# Altium schematic sheet ADXL335_Breakout.SchDoc
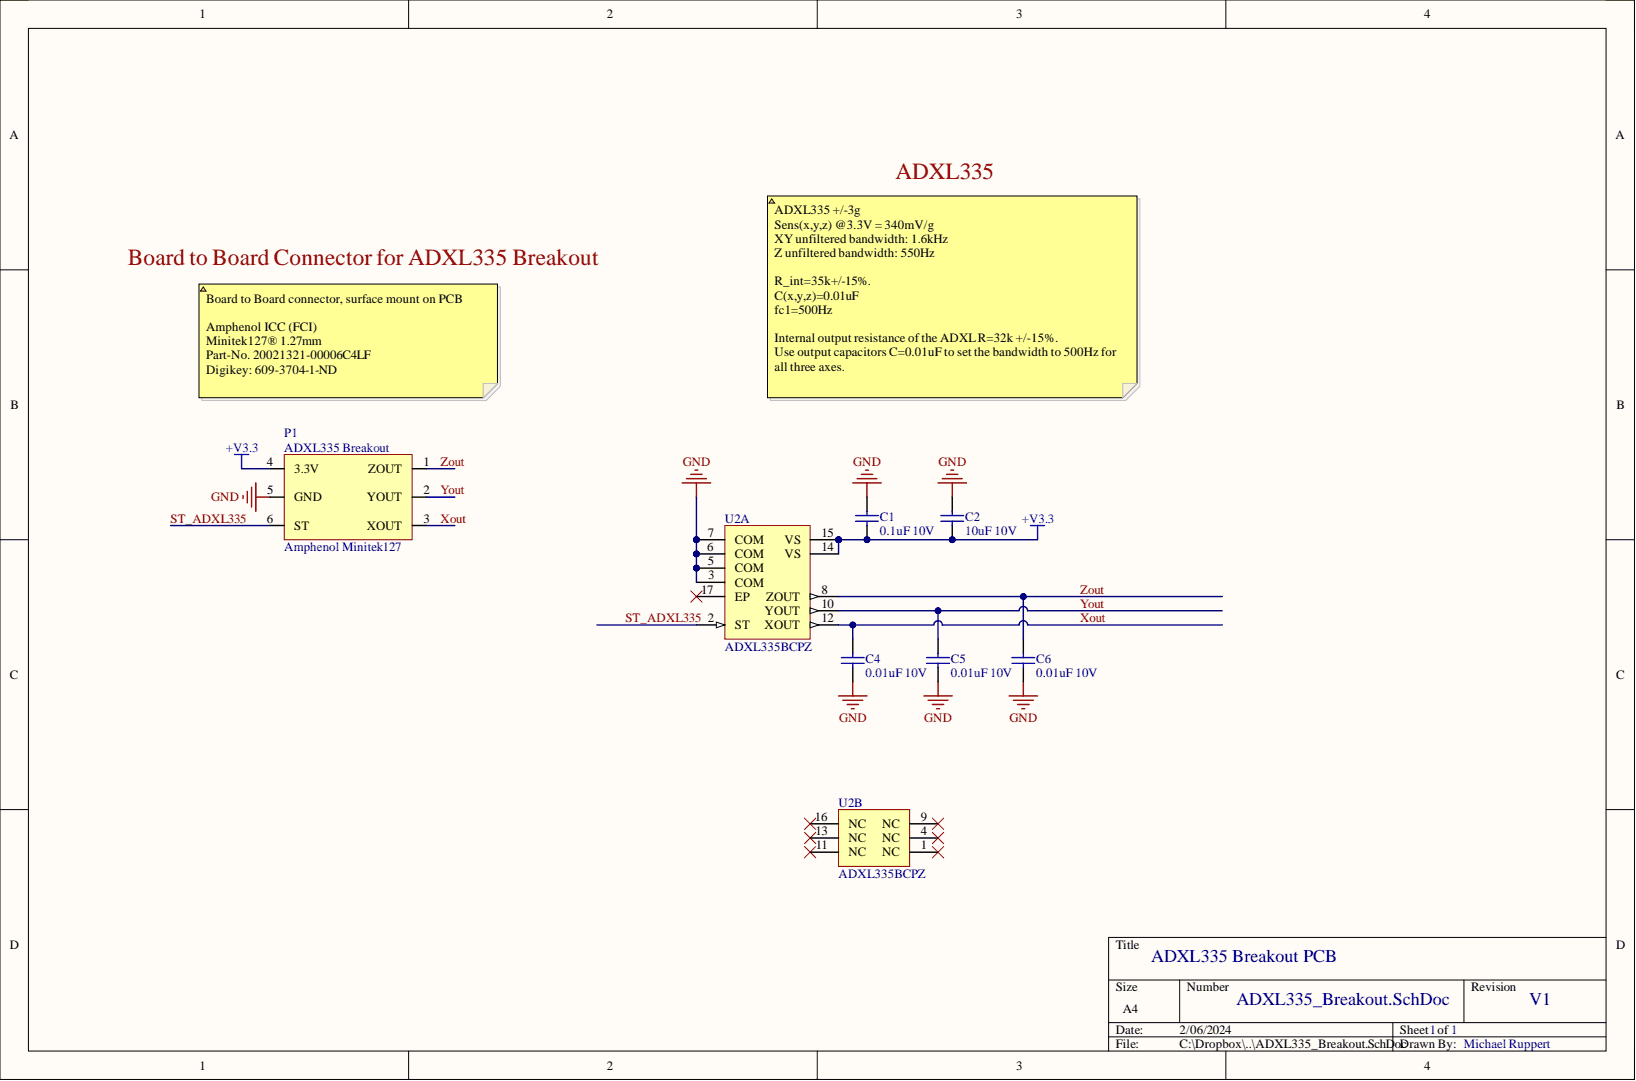
[diagram p0: ADXL335_Breakout.SchDoc]

## Parts
C1  0.1uF 10V  [CAPC1608X08N]
C2  10uF 10V  [CAPC1608X08N]
C4  0.01uF 10V  [CAPC1608X08N]
C5  0.01uF 10V  [CAPC1608X08N]
C6  0.01uF 10V  [CAPC1608X08N]
P1  Amphenol Minitek127  [CON RCPT 6POS 0.05 GOLD SMD]
U2  ADXL335BCPZ  [ADXL335 ADI-CP-16-14_L]  (parts A,B)

## Nets
+V3.3: C1.1 C2.1 P1.4(3.3V) U2.14(VS) U2.15(VS)
GND: C1.2 C2.2 C4.1 C5.1 C6.1 P1.5(GND) U2.3(COM) U2.5(COM) U2.6(COM) U2.7(COM)
ST_ADXL335: P1.6(ST) U2.2(ST)
Xout: C4.2 P1.3(XOUT) U2.12(XOUT)
Yout: C5.2 P1.2(YOUT) U2.10(YOUT)
Zout: C6.2 P1.1(ZOUT) U2.8(ZOUT)

Not connected: U2 1(NC) 4(NC) 9(NC) 11(NC) 13(NC) 16(NC) 17(EP)

## Library data of the parts
C1 = C_0603: Manufacturer: KEMET; Manufacturer Code: C0603C104K8RAC7867; Component Kind: Standard; Digikey Order: 399-C0603C104K8RAC7867CT-ND; Library Name: Schlib_41070.SchLib; Signal Integrity: Cap; Simulation: CAP
C2 = C_0603: Manufacturer: KEMET; Manufacturer Code: C0603C106M8PAC7867; Component Kind: Standard; Digikey Order: 399-14945-1-ND; Library Name: Schlib_41070.SchLib; Signal Integrity: Cap; Simulation: CAP
C4-C6 = C_0603: Manufacturer: KEMET; Manufacturer Code: C0603C103K8RAC7867; Component Kind: Standard; Digikey Order: 399-C0603C103K8RAC7867CT-ND; Library Name: Schlib_41070.SchLib; Signal Integrity: Cap; Simulation: CAP
P1 = CON RCPT 6POS 0.05 GOLD SMD: 6 Position Receptacle Connector 0.050" (1.27mm) Surface Mount Gold; Manufacturer: Amphenol ICC (FCI); Manufacturer Code: 20021321-00006C4LF; Component Kind: Standard; Digikey Order: 609-3704-1-ND; Library Name: Schlib_41070.SchLib
U2 = ADXL335BCPZ: Small, Low Power, 3-Axis +/-3 g Accelerometer with Analog Output, 1.6 KHz BW, Industrial, 16-pin QFN, Tray; Manufacturer: Analog Devices; # of Axes: 3; -3dB Bandwidth: 1.6 KHz; Sensitivity: 300 mV/g; Supply Current: 350 uA; Voltage Supply (V): 1.8 to 3.6; Code_JEDEC: MO-220-WGGD; Component Kind: Standard; ComponentLink1Description: Manufacturer URL; ComponentLink1URL: http://www.analog.com/en/index.html; ComponentLink2Description: Datasheet; ComponentLink2URL: http://www.analog.com/static/imported-files/data_sheets/ADXL335.pdf; ComponentLink3Description: Package Specification; ComponentLink3URL: http:/www.analog.com/static/imported-files/packages/PKG_PDF/LFCSP(CP)/CP-16/CP_16_14.pdf; ComponentLink4Description: Product URL; ComponentLink4URL: http://www.analog.com/en/mems-sensors/mems-inertial-sensors/adxl335/products/product.html; DatasheetVersion: Rev. B; Digikey Order: 505-ADXL335BCPZ-ND; Library Name: Schlib_41070.SchLib; Manufacturer Code: ADXL335BCPZ; Mounting Technology: Surface Mount; Output Type: Analog; PackageDescription: 16-Pin Lead Frame Chip Scale Package, 4 x 4 mm Body, 0.65 mm Pitch; PackageReference: CP-16-14; PackageVersion: 051909-A, 05/2009; Packing: Tray; PartNumber: ADXL335BCPZ
